# Altium schematic sheet isg_eos_control_board_xem3001.SchDoc
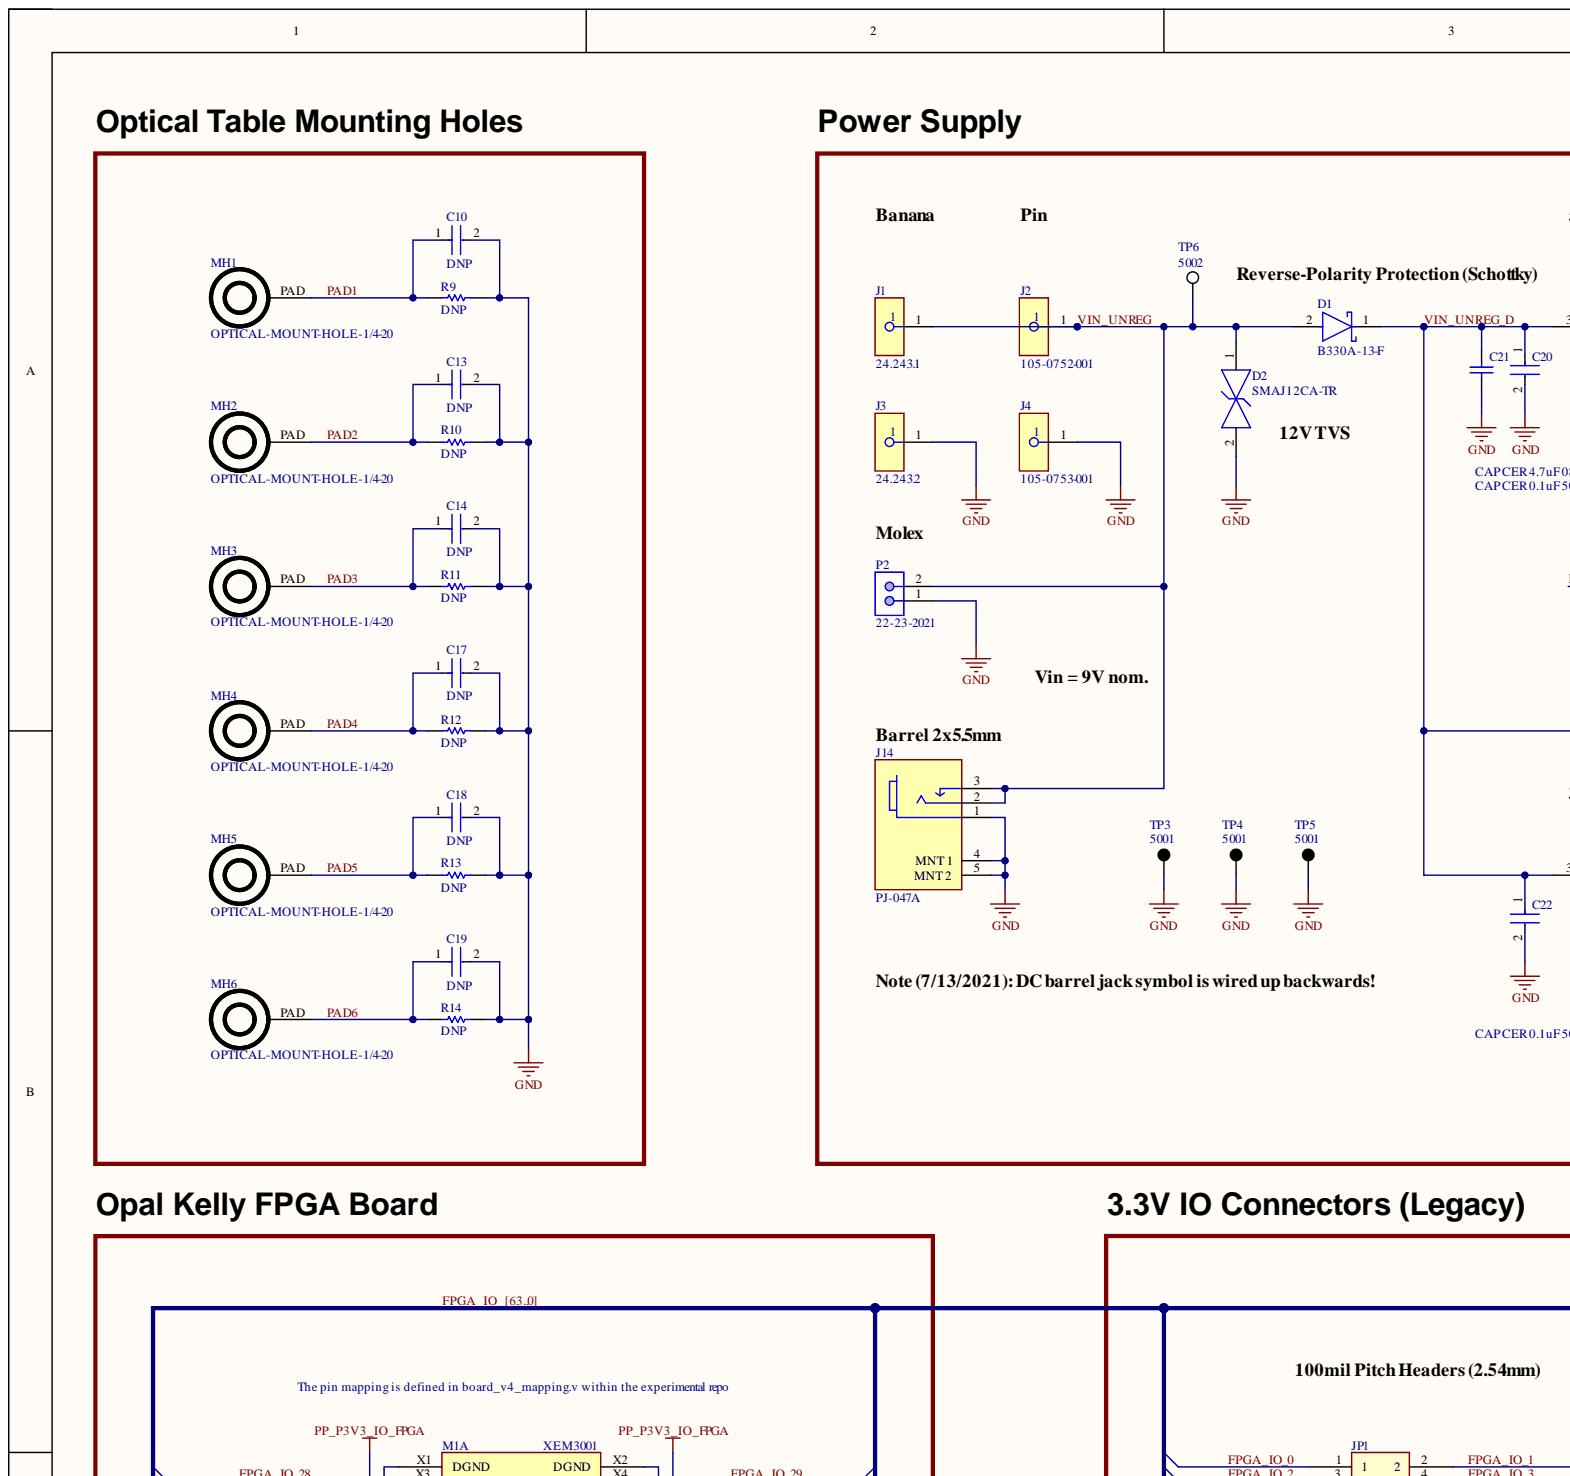
[diagram p0: isg_eos_control_board_xem3001.SchDoc, top left]
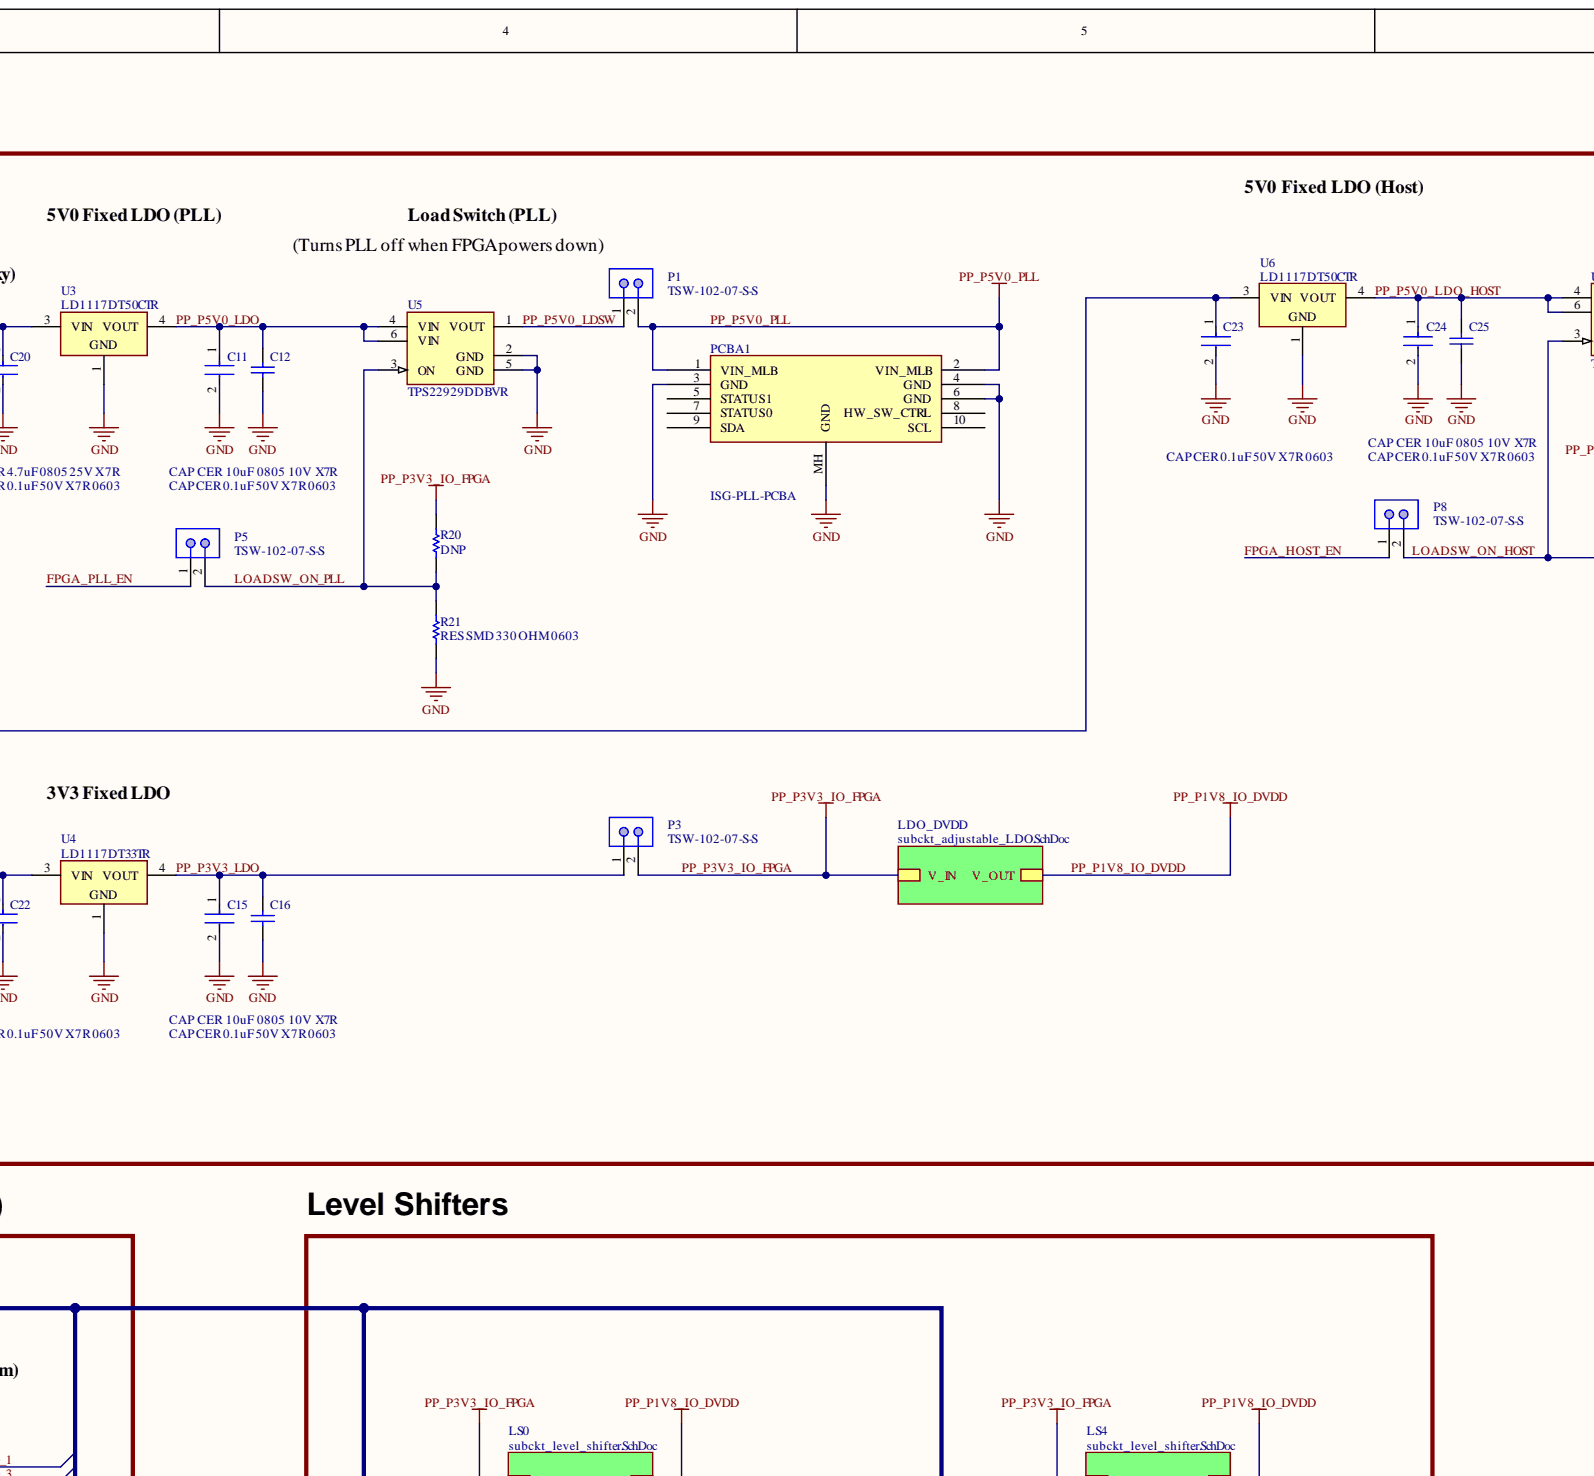
[diagram p1: isg_eos_control_board_xem3001.SchDoc, top centre]
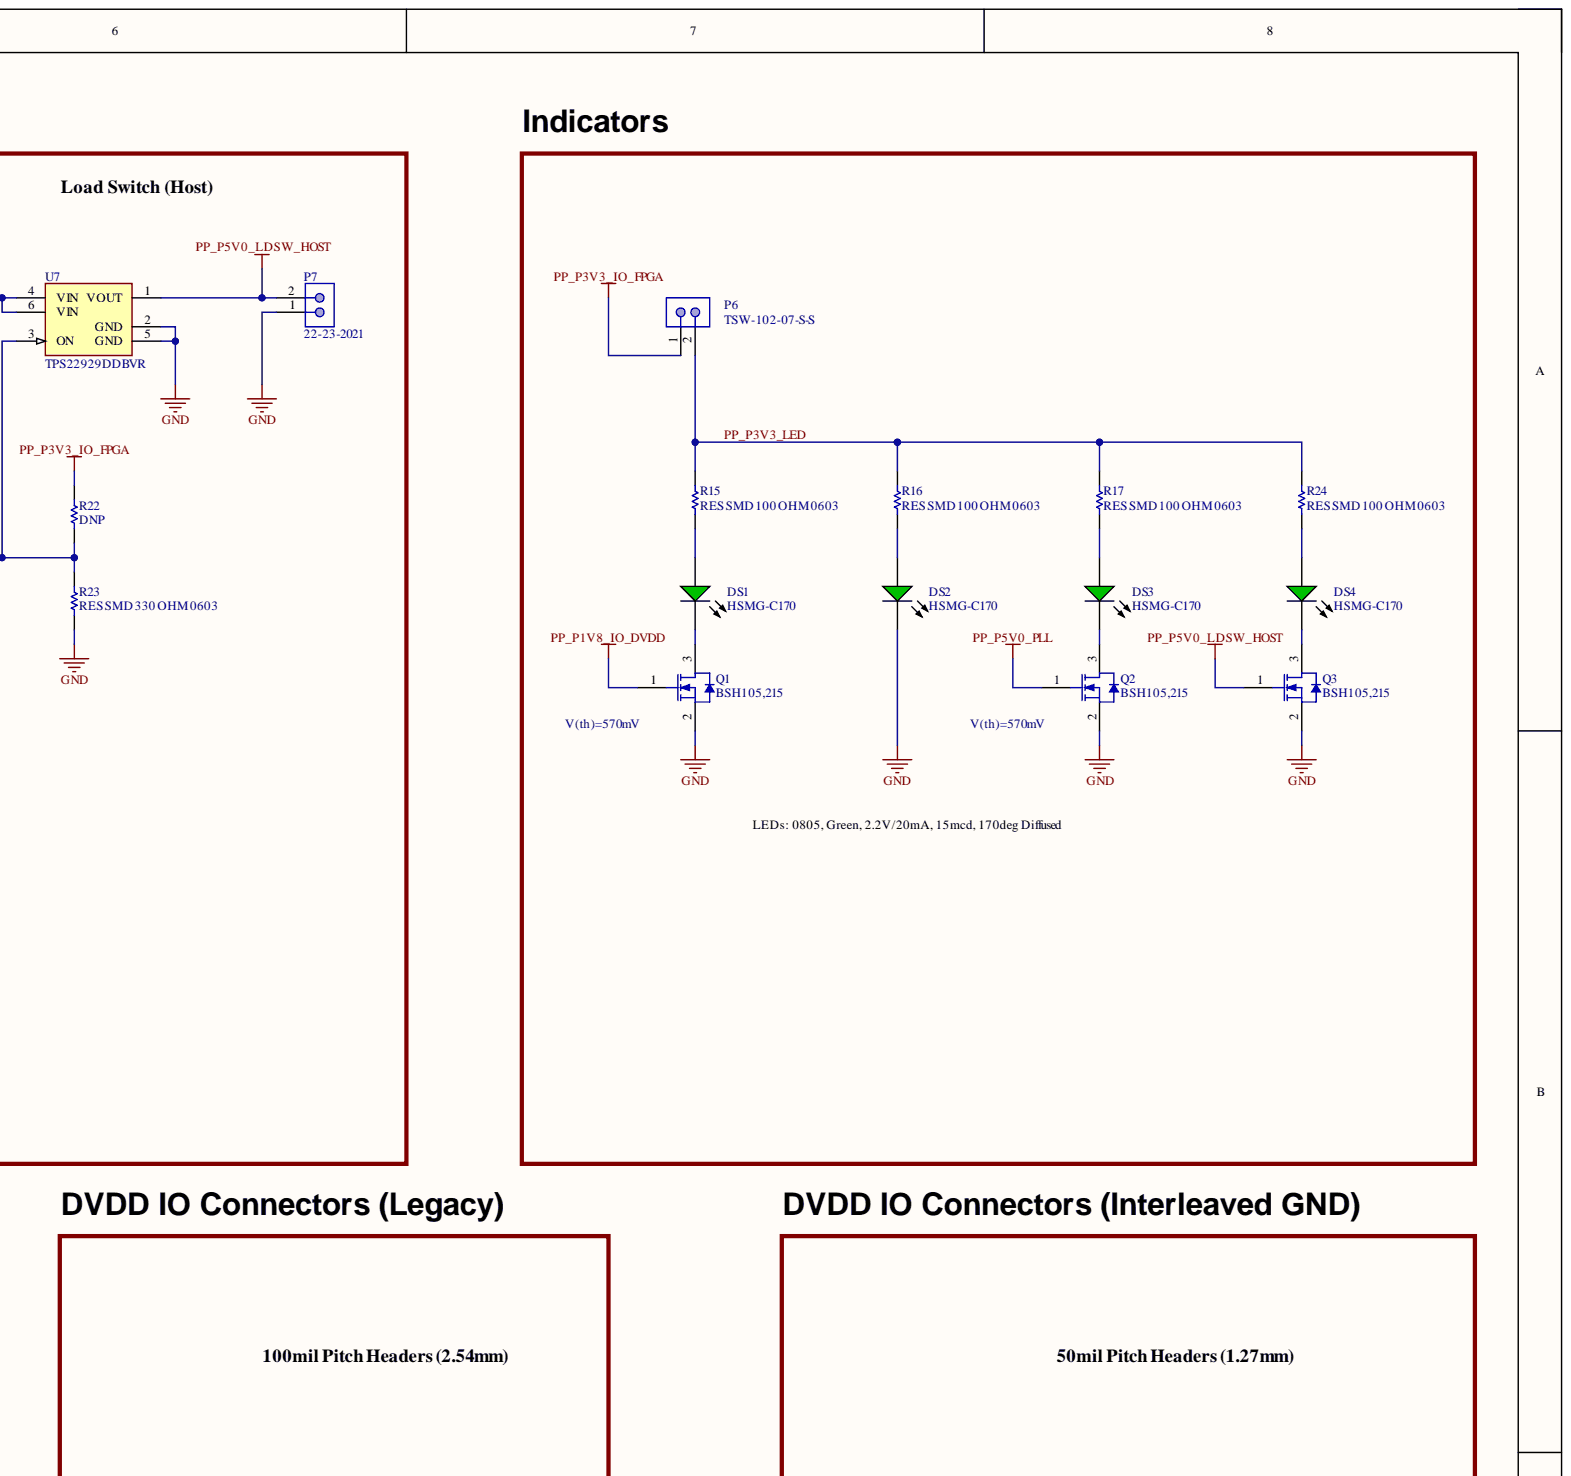
[diagram p2: isg_eos_control_board_xem3001.SchDoc, top right]
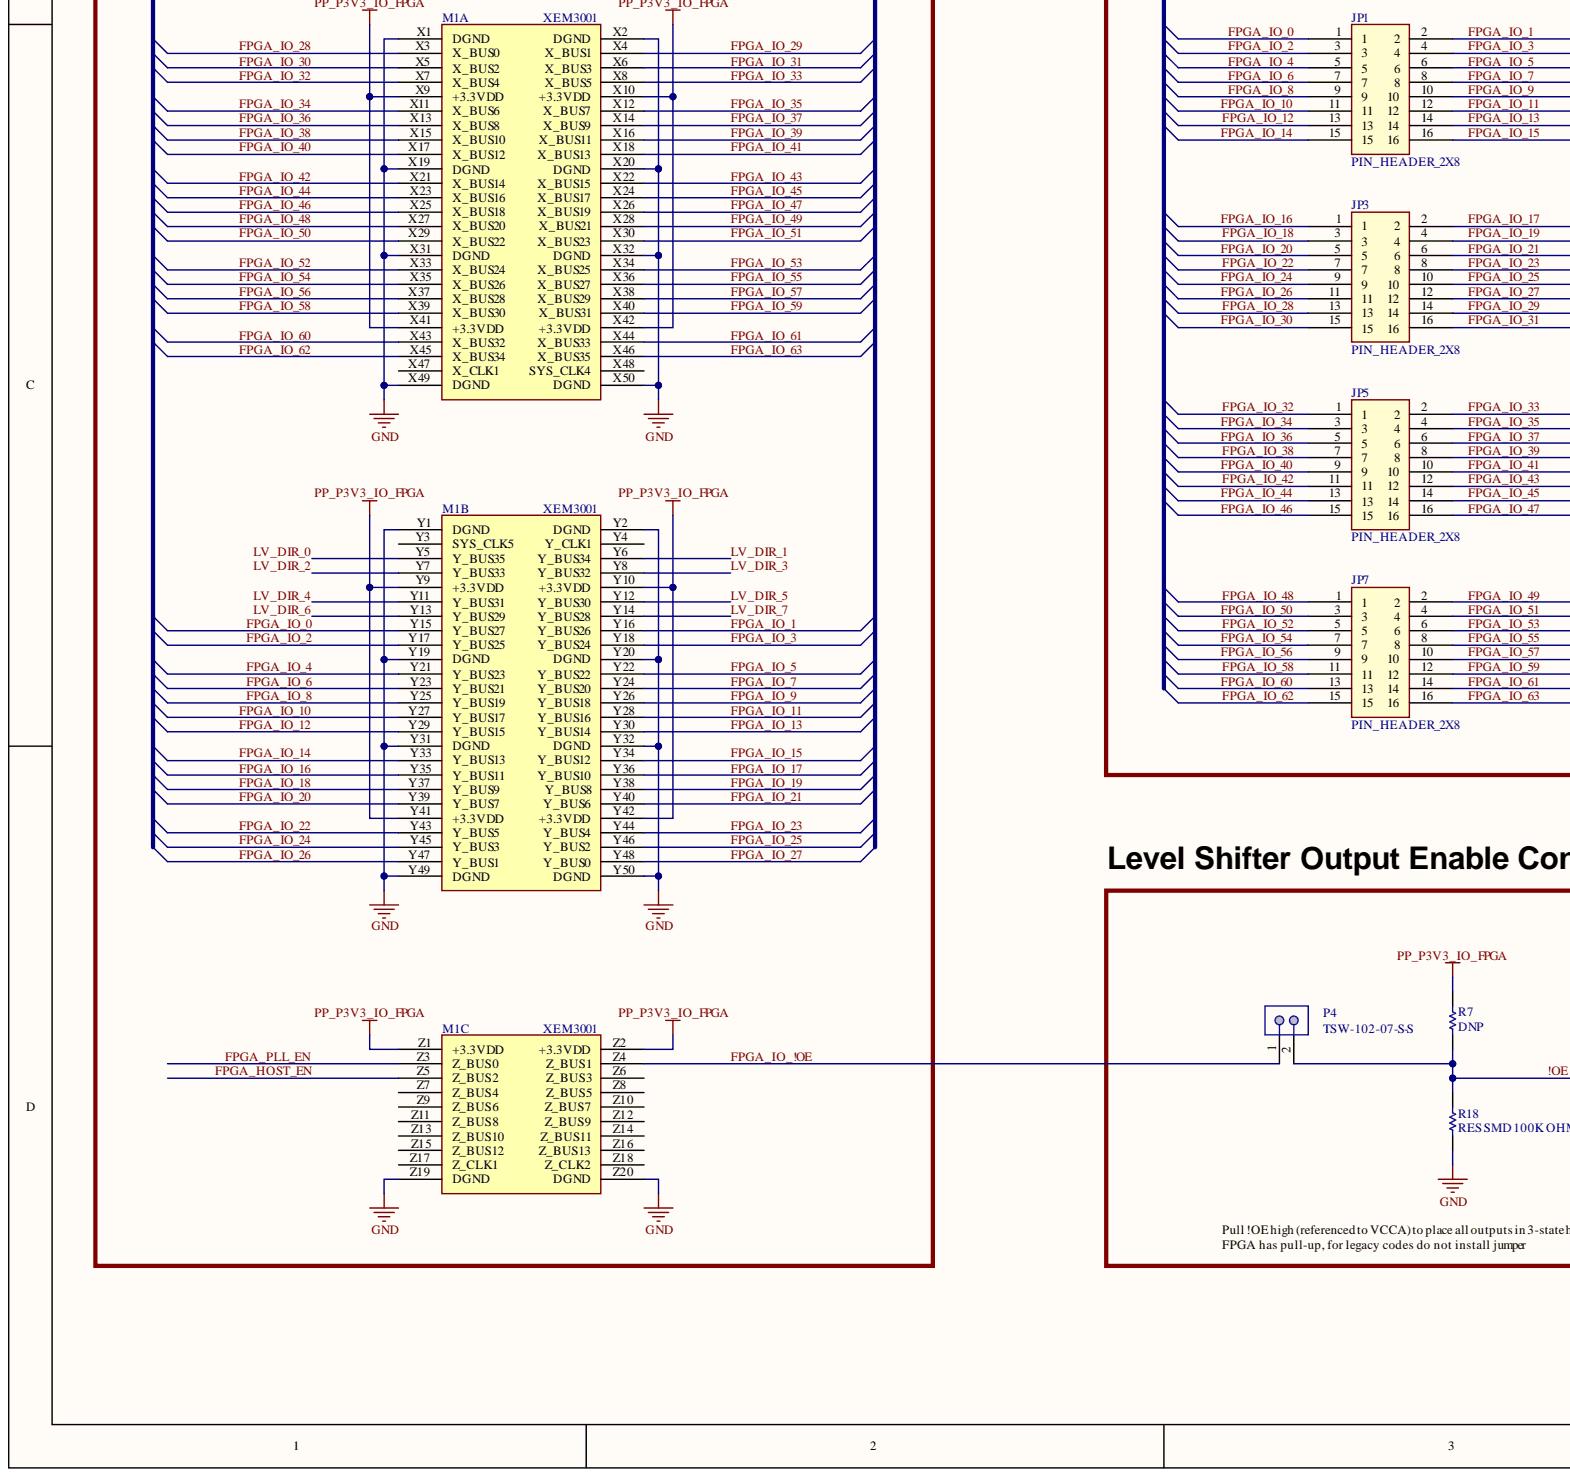
[diagram p3: isg_eos_control_board_xem3001.SchDoc, bottom left]
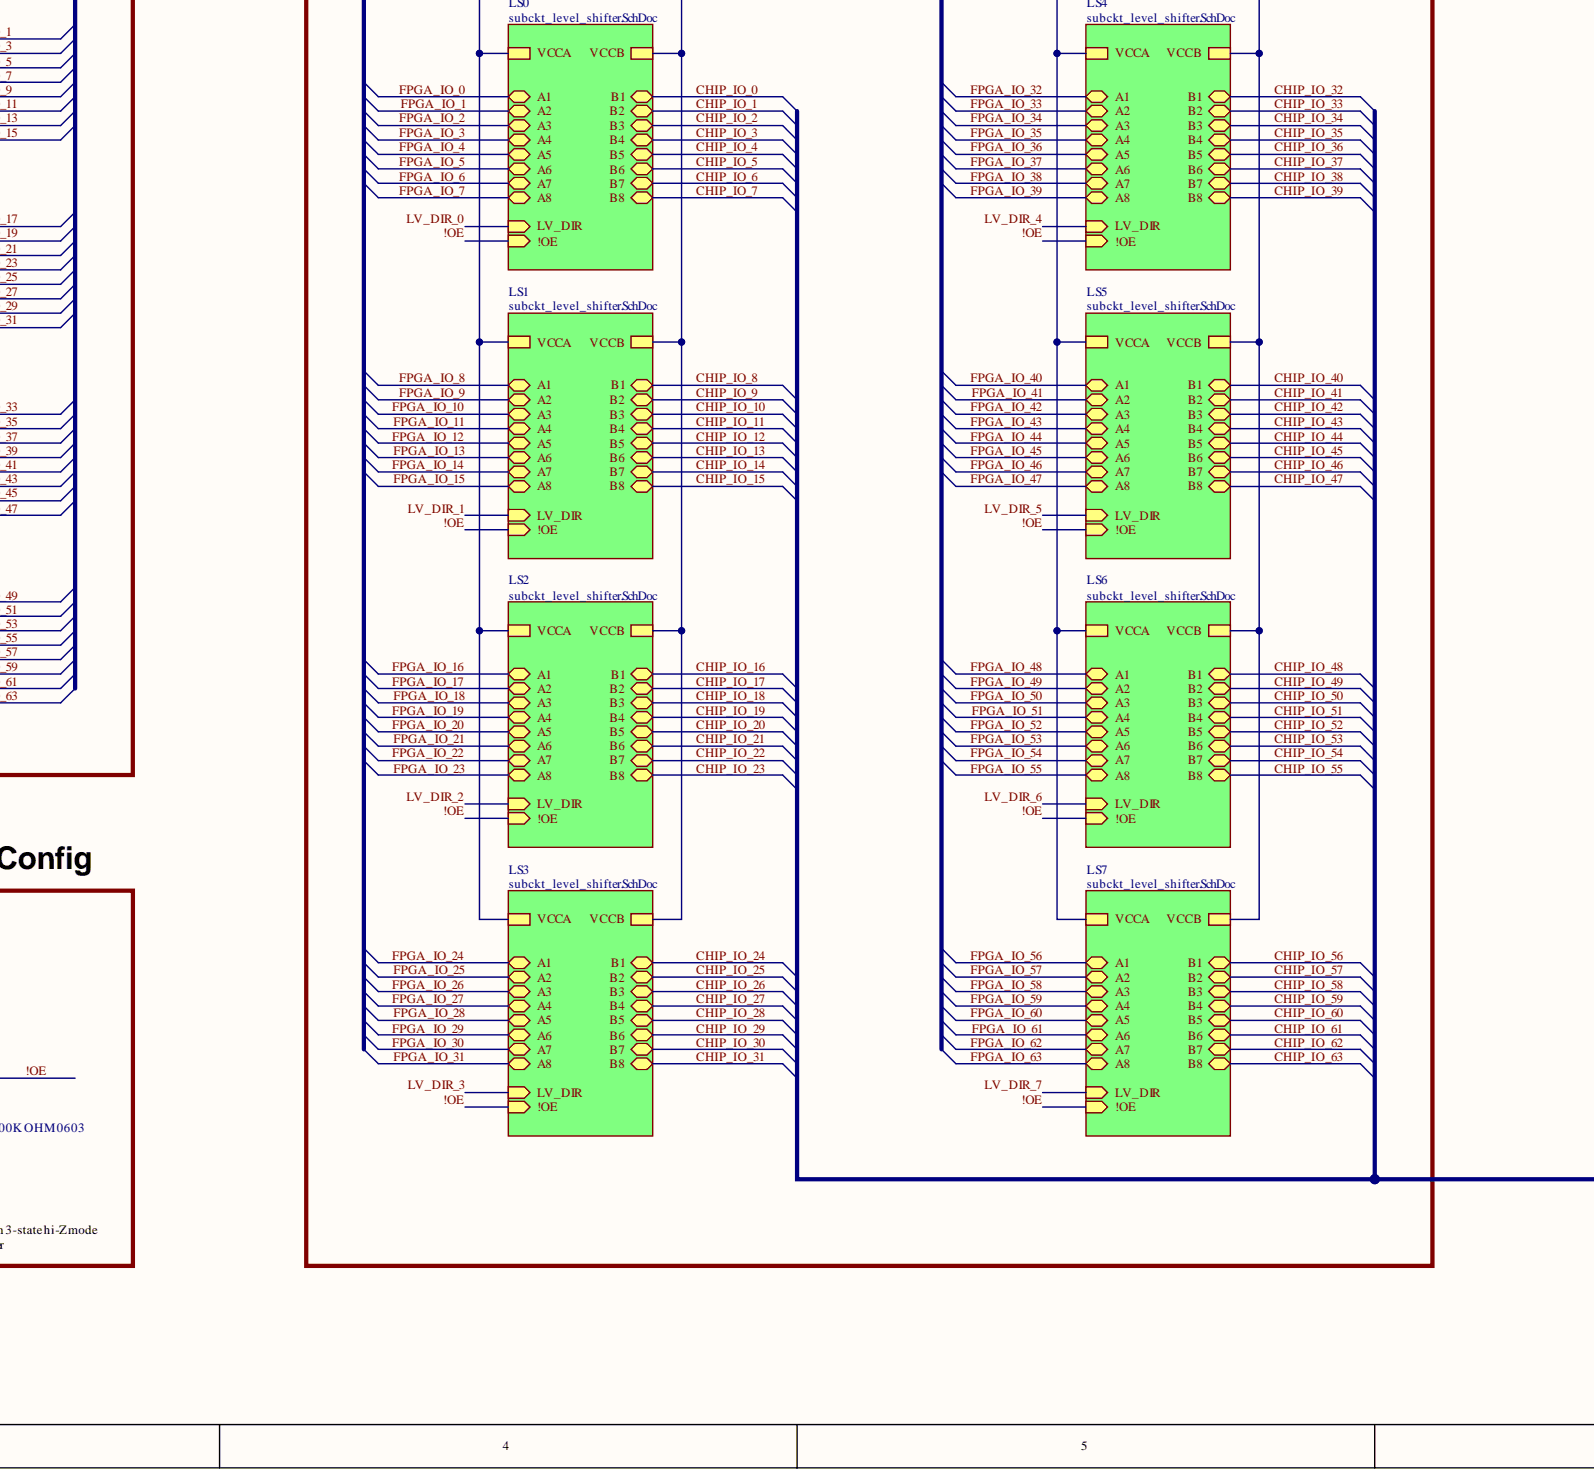
[diagram p4: isg_eos_control_board_xem3001.SchDoc, bottom centre]
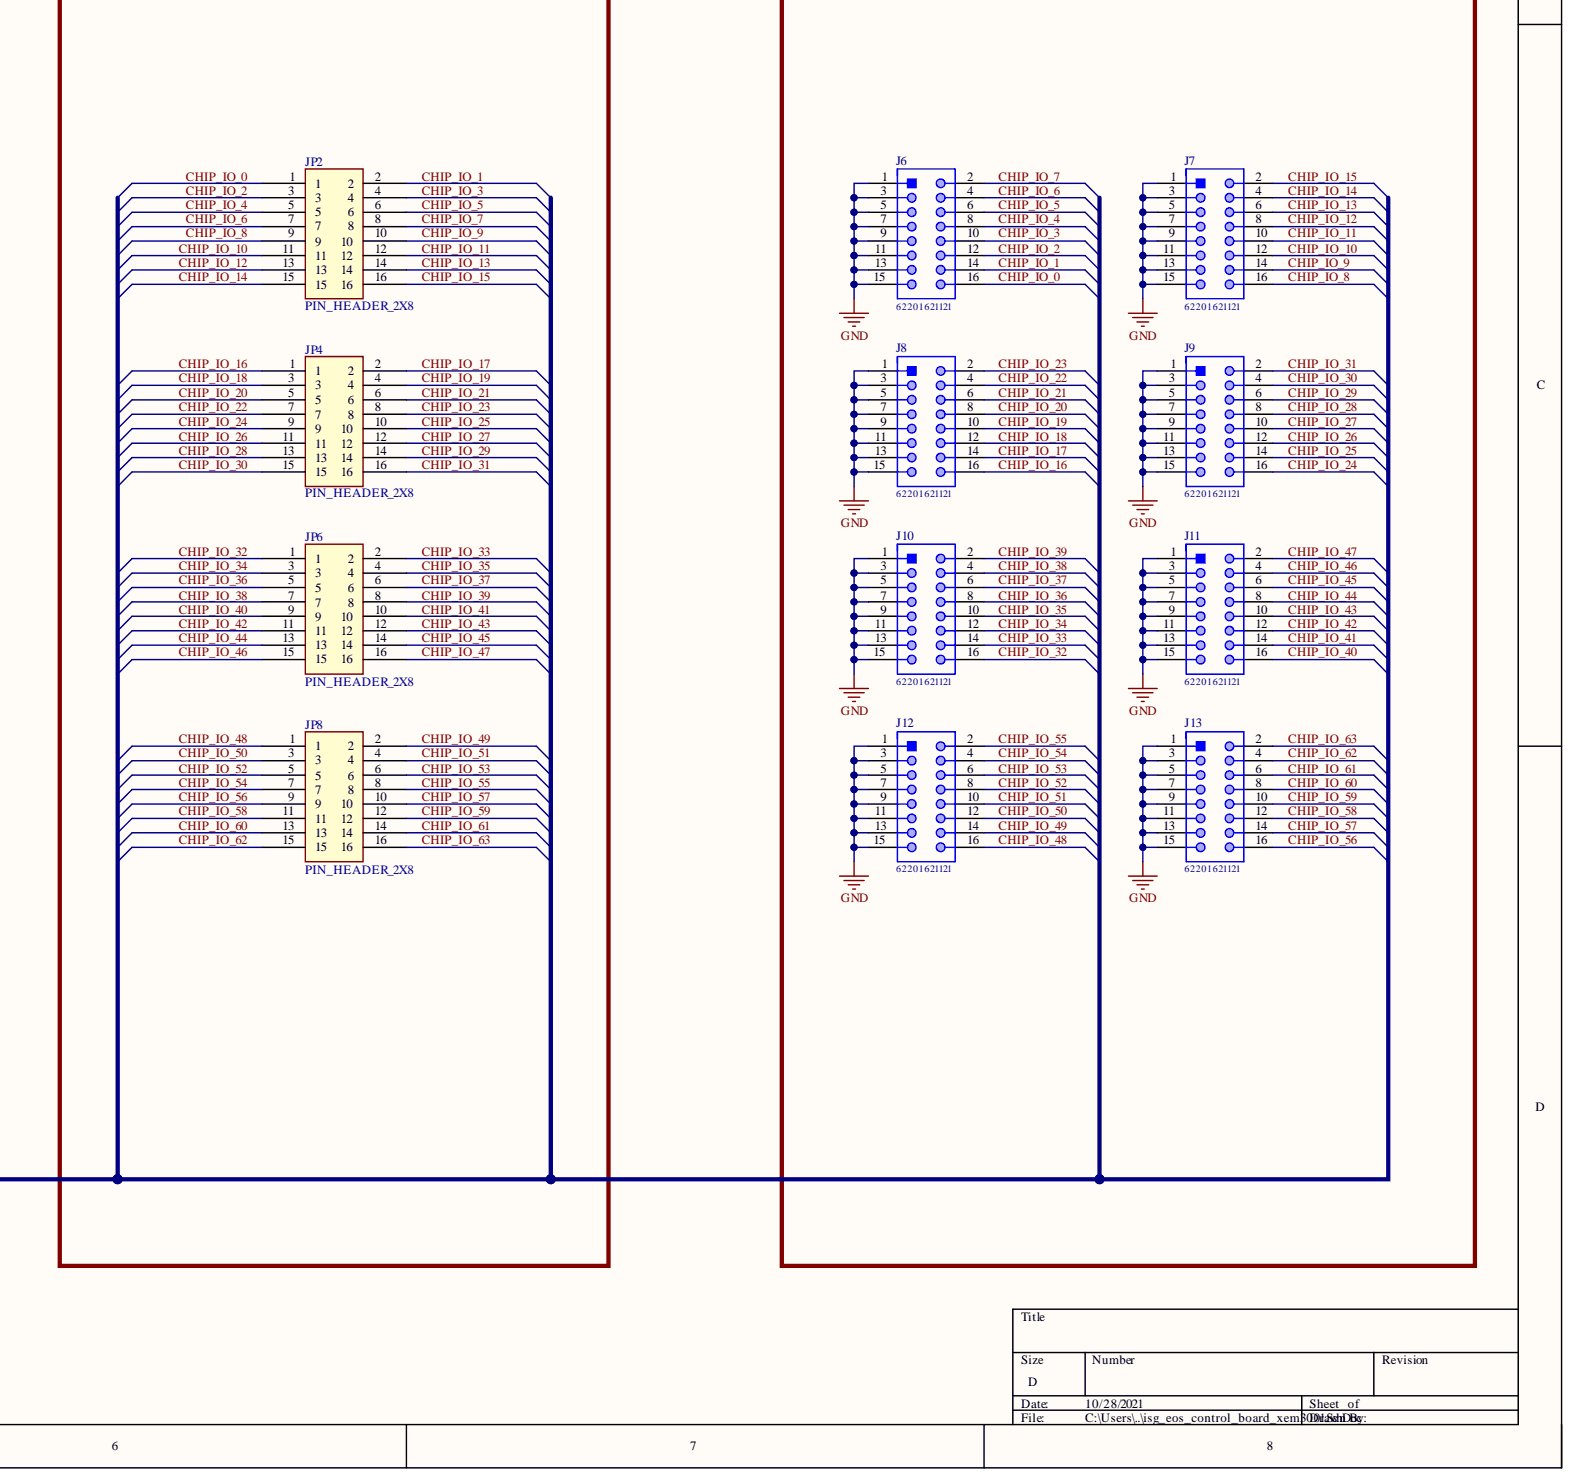
[diagram p5: isg_eos_control_board_xem3001.SchDoc, bottom right]

## Parts
C10  DNP  [FP-CC0603-DA-MFG]
C11  CAP CER 0.1uF 50V X7R 0603  [FP-CC0603-DA-MFG]
C12  CAP CER 10uF 0805 10V X7R  [CAPC2013X140X50NL20T25]
C13  DNP  [FP-CC0603-DA-MFG]
C14  DNP  [FP-CC0603-DA-MFG]
C15  CAP CER 0.1uF 50V X7R 0603  [FP-CC0603-DA-MFG]
C16  CAP CER 10uF 0805 10V X7R  [CAPC2013X140X50NL20T25]
C17  DNP  [FP-CC0603-DA-MFG]
C18  DNP  [FP-CC0603-DA-MFG]
C19  DNP  [FP-CC0603-DA-MFG]
C20  CAP CER 0.1uF 50V X7R 0603  [FP-CC0603-DA-MFG]
C21  CAP CER 4.7uF 0805 25V X7R  [CAPC2013X140X50NL20T25]
C22  CAP CER 0.1uF 50V X7R 0603  [FP-CC0603-DA-MFG]
C23  CAP CER 0.1uF 50V X7R 0603  [FP-CC0603-DA-MFG]
C24  CAP CER 0.1uF 50V X7R 0603  [FP-CC0603-DA-MFG]
C25  CAP CER 10uF 0805 10V X7R  [CAPC2013X140X50NL20T25]
D1  B330A-13-F  [FP-SMA-MFG]
D2  SMAJ12CA-TR  Reverse Standoff Voltage: 12V  [FP-SMA-CA-MFG]
DS1  HSMG-C170  [AVAG-HSMX-C170_V]
DS2  HSMG-C170  [AVAG-HSMX-C170_V]
DS3  HSMG-C170  [AVAG-HSMX-C170_V]
DS4  HSMG-C170  [AVAG-HSMX-C170_V]
J1  24.243.1  [FP-24_243_1-MFG]
J2  105-0752-001  [FP-105-0751-001-MFG]
J3  24.243.2  [FP-24_243_2-MFG]
J4  105-0753-001  [FP-105-0751-001-MFG]
J6  62201621121  [62201621121]
J7  62201621121  [62201621121]
J8  62201621121  [62201621121]
J9  62201621121  [62201621121]
J10  62201621121  [62201621121]
J11  62201621121  [62201621121]
J12  62201621121  [62201621121]
J13  62201621121  [62201621121]
J14  PJ-047A  [FP-PJ-047A-MFG]
JP1  PIN_HEADER_2X8  [PIN_HEADER_2X8_2.54MM]
JP2  PIN_HEADER_2X8  [PIN_HEADER_2X8_2.54MM]
JP3  PIN_HEADER_2X8  [PIN_HEADER_2X8_2.54MM]
JP4  PIN_HEADER_2X8  [PIN_HEADER_2X8_2.54MM]
JP5  PIN_HEADER_2X8  [PIN_HEADER_2X8_2.54MM]
JP6  PIN_HEADER_2X8  [PIN_HEADER_2X8_2.54MM]
JP7  PIN_HEADER_2X8  [PIN_HEADER_2X8_2.54MM]
JP8  PIN_HEADER_2X8  [PIN_HEADER_2X8_2.54MM]
M1  XEM3001  [XEM3001MODULE]  (parts A,B,C)
MH1  OPTICAL-MOUNT-HOLE-1/4-20  [MH-1/4-20]
MH2  OPTICAL-MOUNT-HOLE-1/4-20  [MH-1/4-20]
MH3  OPTICAL-MOUNT-HOLE-1/4-20  [MH-1/4-20]
MH4  OPTICAL-MOUNT-HOLE-1/4-20  [MH-1/4-20]
MH5  OPTICAL-MOUNT-HOLE-1/4-20  [MH-1/4-20]
MH6  OPTICAL-MOUNT-HOLE-1/4-20  [MH-1/4-20]
P1  TSW-102-07-S-S  [SMTC-TSW-102-07-S-S]
P2  22-23-2021  [MOLX-22-23-2021_V]
P3  TSW-102-07-S-S  [SMTC-TSW-102-07-S-S]
P4  TSW-102-07-S-S  [SMTC-TSW-102-07-S-S]
P5  TSW-102-07-S-S  [SMTC-TSW-102-07-S-S]
P6  TSW-102-07-S-S  [SMTC-TSW-102-07-S-S]
P7  22-23-2021  [MOLX-22-23-2021_V]
P8  TSW-102-07-S-S  [SMTC-TSW-102-07-S-S]
PCBA1  ISG-PLL-PCBA  [ISG-PLL-PCBA]
Q1  BSH105,215  [NXP-SOT23_M]
Q2  BSH105,215  [NXP-SOT23_M]
Q3  BSH105,215  [NXP-SOT23_M]
R7  DNP  [RESC1609X50X30LL10T20]
R9  DNP  [RESC1609X50X30LL10T20]
R10  DNP  [RESC1609X50X30LL10T20]
R11  DNP  [RESC1609X50X30LL10T20]
R12  DNP  [RESC1609X50X30LL10T20]
R13  DNP  [RESC1609X50X30LL10T20]
R14  DNP  [RESC1609X50X30LL10T20]
R15  RES SMD 100 OHM 0603  [RESC1609X50X30LL10T20]
R16  RES SMD 100 OHM 0603  [RESC1609X50X30LL10T20]
R17  RES SMD 100 OHM 0603  [RESC1609X50X30LL10T20]
R18  RES SMD 100K OHM 0603  [RESC1609X50X30LL10T20]
R20  DNP  [RESC1609X50X30LL10T20]
R21  RES SMD 330 OHM 0603  [RESC1609X50X30LL10T20]
R22  DNP  [RESC1609X50X30LL10T20]
R23  RES SMD 330 OHM 0603  [RESC1609X50X30LL10T20]
R24  RES SMD 100 OHM 0603  [RESC1609X50X30LL10T20]
TP3  5001  [KSTN-5001]
TP4  5001  [KSTN-5001]
TP5  5001  [KSTN-5001]
TP6  5002  [KSTN-5002]
U3  LD1117DT50CTR  [TO-252_N]
U4  LD1117DT33TR  [TO-252_N]
U5  TPS22929DDBVR  [DBV0006A_M]
U6  LD1117DT50CTR  [TO-252_N]
U7  TPS22929DDBVR  [DBV0006A_M]

## Nets
GND: C10.2 C11.2 C12.2 C13.2 C14.2 C15.2 C16.2 C17.2 C18.2 C19.2 C20.2 C21.2 C22.2 C23.2 C24.2 C25.2 D2.2 DS2.2 J3.1 J4.1 J6.1 J6.3 J6.5 J6.7 J6.9 J6.11 J6.13 J6.15 J7.1 J7.3 J7.5 J7.7 J7.9 J7.11 J7.13 J7.15 J8.1 J8.3 J8.5 J8.7 J8.9 J8.11 J8.13 J8.15 J9.1 J9.3 J9.5 J9.7 J9.9 J9.11 J9.13 J9.15 J10.1 J10.3 J10.5 J10.7 J10.9 J10.11 J10.13 J10.15 J11.1 J11.3 J11.5 J11.7 J11.9 J11.11 J11.13 J11.15 J12.1 J12.3 J12.5 J12.7 J12.9 J12.11 J12.13 J12.15 J13.1 J13.3 J13.5 J13.7 J13.9 J13.11 J13.13 J13.15 J14.1 J14.4(MNT_1) J14.5(MNT_2) M1.X1(DGND) M1.X2(DGND) M1.X19(DGND) M1.X20(DGND) M1.X31(DGND) M1.X32(DGND) M1.X49(DGND) M1.X50(DGND) M1.Y1(DGND) M1.Y2(DGND) M1.Y19(DGND) M1.Y20(DGND) M1.Y31(DGND) M1.Y32(DGND) M1.Y49(DGND) M1.Y50(DGND) M1.Z19(DGND) M1.Z20(DGND) P2.1 P7.1 PCBA1.3(GND) PCBA1.4(GND) PCBA1.6(GND) PCBA1.MH(GND) Q1.2 Q2.2 Q3.2 R9.2 R10.2 R11.2 R12.2 R13.2 R14.2 R18.2 R21.2 R23.2 TP3.1 TP4.1 TP5.1 U3.1 U4.1 U5.2(GND) U5.5(GND) U6.1 U7.2(GND) U7.5(GND)
PP_P5V0_LDSW_HOST: P7.2 Q3.1 U7.1(VOUT)
!OE: P4.2 R7.2 R18.1
CHIP_IO_0: J6.16 JP2.1
CHIP_IO_1: J6.14 JP2.2
CHIP_IO_2: J6.12 JP2.3
CHIP_IO_3: J6.10 JP2.4
CHIP_IO_4: J6.8 JP2.5
CHIP_IO_5: J6.6 JP2.6
CHIP_IO_6: J6.4 JP2.7
CHIP_IO_7: J6.2 JP2.8
CHIP_IO_8: J7.16 JP2.9
CHIP_IO_9: J7.14 JP2.10
CHIP_IO_10: J7.12 JP2.11
CHIP_IO_11: J7.10 JP2.12
CHIP_IO_12: J7.8 JP2.13
CHIP_IO_13: J7.6 JP2.14
CHIP_IO_14: J7.4 JP2.15
CHIP_IO_15: J7.2 JP2.16
CHIP_IO_16: J8.16 JP4.1
CHIP_IO_17: J8.14 JP4.2
CHIP_IO_18: J8.12 JP4.3
CHIP_IO_19: J8.10 JP4.4
CHIP_IO_20: J8.8 JP4.5
CHIP_IO_21: J8.6 JP4.6
CHIP_IO_22: J8.4 JP4.7
CHIP_IO_23: J8.2 JP4.8
CHIP_IO_24: J9.16 JP4.9
CHIP_IO_25: J9.14 JP4.10
CHIP_IO_26: J9.12 JP4.11
CHIP_IO_27: J9.10 JP4.12
CHIP_IO_28: J9.8 JP4.13
CHIP_IO_29: J9.6 JP4.14
CHIP_IO_30: J9.4 JP4.15
CHIP_IO_31: J9.2 JP4.16
CHIP_IO_32: J10.16 JP6.1
CHIP_IO_33: J10.14 JP6.2
CHIP_IO_34: J10.12 JP6.3
CHIP_IO_35: J10.10 JP6.4
CHIP_IO_36: J10.8 JP6.5
CHIP_IO_37: J10.6 JP6.6
CHIP_IO_38: J10.4 JP6.7
CHIP_IO_39: J10.2 JP6.8
CHIP_IO_40: J11.16 JP6.9
CHIP_IO_41: J11.14 JP6.10
CHIP_IO_42: J11.12 JP6.11
CHIP_IO_43: J11.10 JP6.12
CHIP_IO_44: J11.8 JP6.13
CHIP_IO_45: J11.6 JP6.14
CHIP_IO_46: J11.4 JP6.15
CHIP_IO_47: J11.2 JP6.16
CHIP_IO_48: J12.16 JP8.1
CHIP_IO_49: J12.14 JP8.2
CHIP_IO_50: J12.12 JP8.3
CHIP_IO_51: J12.10 JP8.4
CHIP_IO_52: J12.8 JP8.5
CHIP_IO_53: J12.6 JP8.6
CHIP_IO_54: J12.4 JP8.7
CHIP_IO_55: J12.2 JP8.8
CHIP_IO_56: J13.16 JP8.9
CHIP_IO_57: J13.14 JP8.10
CHIP_IO_58: J13.12 JP8.11
CHIP_IO_59: J13.10 JP8.12
CHIP_IO_60: J13.8 JP8.13
CHIP_IO_61: J13.6 JP8.14
CHIP_IO_62: J13.4 JP8.15
CHIP_IO_63: J13.2 JP8.16
FPGA_HOST_EN: M1.Z5(Z_BUS2) P8.1
FPGA_IO_0: JP1.1 M1.Y15(Y_BUS27)  [also labelled FPGA_IO_]
FPGA_IO_1: JP1.2 M1.Y16(Y_BUS26)  [also labelled FPGA_IO_]
FPGA_IO_2: JP1.3 M1.Y17(Y_BUS25)  [also labelled FPGA_IO_]
FPGA_IO_3: JP1.4 M1.Y18(Y_BUS24)  [also labelled FPGA_IO_]
FPGA_IO_4: JP1.5 M1.Y21(Y_BUS23)  [also labelled FPGA_IO_]
FPGA_IO_5: JP1.6 M1.Y22(Y_BUS22)  [also labelled FPGA_IO_]
FPGA_IO_6: JP1.7 M1.Y23(Y_BUS21)  [also labelled FPGA_IO_]
FPGA_IO_7: JP1.8 M1.Y24(Y_BUS20)  [also labelled FPGA_IO_]
FPGA_IO_8: JP1.9 M1.Y25(Y_BUS19)  [also labelled FPGA_IO_]
FPGA_IO_9: JP1.10 M1.Y26(Y_BUS18)  [also labelled FPGA_IO_]
FPGA_IO_10: JP1.11 M1.Y27(Y_BUS17)  [also labelled FPGA_IO_]
FPGA_IO_11: JP1.12 M1.Y28(Y_BUS16)  [also labelled FPGA_IO_]
FPGA_IO_12: JP1.13 M1.Y29(Y_BUS15)  [also labelled FPGA_IO_]
FPGA_IO_13: JP1.14 M1.Y30(Y_BUS14)  [also labelled FPGA_IO_]
FPGA_IO_14: JP1.15 M1.Y33(Y_BUS13)  [also labelled FPGA_IO_]
FPGA_IO_15: JP1.16 M1.Y34(Y_BUS12)  [also labelled FPGA_IO_]
FPGA_IO_16: JP3.1 M1.Y35(Y_BUS11)  [also labelled FPGA_IO_]
FPGA_IO_17: JP3.2 M1.Y36(Y_BUS10)  [also labelled FPGA_IO_]
FPGA_IO_18: JP3.3 M1.Y37(Y_BUS9)  [also labelled FPGA_IO_]
FPGA_IO_19: JP3.4 M1.Y38(Y_BUS8)  [also labelled FPGA_IO_]
FPGA_IO_20: JP3.5 M1.Y39(Y_BUS7)  [also labelled FPGA_IO_]
FPGA_IO_21: JP3.6 M1.Y40(Y_BUS6)  [also labelled FPGA_IO_]
FPGA_IO_22: JP3.7 M1.Y43(Y_BUS5)  [also labelled FPGA_IO_]
FPGA_IO_23: JP3.8 M1.Y44(Y_BUS4)  [also labelled FPGA_IO_]
FPGA_IO_24: JP3.9 M1.Y45(Y_BUS3)  [also labelled FPGA_IO_]
FPGA_IO_25: JP3.10 M1.Y46(Y_BUS2)  [also labelled FPGA_IO_]
FPGA_IO_26: JP3.11 M1.Y47(Y_BUS1)  [also labelled FPGA_IO_]
FPGA_IO_27: JP3.12 M1.Y48(Y_BUS0)  [also labelled FPGA_IO_]
FPGA_IO_28: JP3.13 M1.X3(X_BUS0)  [also labelled FPGA_IO_]
FPGA_IO_29: JP3.14 M1.X4(X_BUS1)  [also labelled FPGA_IO_]
FPGA_IO_30: JP3.15 M1.X5(X_BUS2)  [also labelled FPGA_IO_]
FPGA_IO_31: JP3.16 M1.X6(X_BUS3)  [also labelled FPGA_IO_]
FPGA_IO_32: JP5.1 M1.X7(X_BUS4)  [also labelled FPGA_IO_]
FPGA_IO_33: JP5.2 M1.X8(X_BUS5)  [also labelled FPGA_IO_]
FPGA_IO_34: JP5.3 M1.X11(X_BUS6)  [also labelled FPGA_IO_]
FPGA_IO_35: JP5.4 M1.X12(X_BUS7)  [also labelled FPGA_IO_]
FPGA_IO_36: JP5.5 M1.X13(X_BUS8)  [also labelled FPGA_IO_]
FPGA_IO_37: JP5.6 M1.X14(X_BUS9)  [also labelled FPGA_IO_]
FPGA_IO_38: JP5.7 M1.X15(X_BUS10)  [also labelled FPGA_IO_]
FPGA_IO_39: JP5.8 M1.X16(X_BUS11)  [also labelled FPGA_IO_]
FPGA_IO_40: JP5.9 M1.X17(X_BUS12)  [also labelled FPGA_IO_]
FPGA_IO_41: JP5.10 M1.X18(X_BUS13)  [also labelled FPGA_IO_]
FPGA_IO_42: JP5.11 M1.X21(X_BUS14)  [also labelled FPGA_IO_]
FPGA_IO_43: JP5.12 M1.X22(X_BUS15)  [also labelled FPGA_IO_]
FPGA_IO_44: JP5.13 M1.X23(X_BUS16)  [also labelled FPGA_IO_]
FPGA_IO_45: JP5.14 M1.X24(X_BUS17)  [also labelled FPGA_IO_]
FPGA_IO_46: JP5.15 M1.X25(X_BUS18)  [also labelled FPGA_IO_]
FPGA_IO_47: JP5.16 M1.X26(X_BUS19)  [also labelled FPGA_IO_]
FPGA_IO_48: JP7.1 M1.X27(X_BUS20)  [also labelled FPGA_IO_]
FPGA_IO_49: JP7.2 M1.X28(X_BUS21)  [also labelled FPGA_IO_]
FPGA_IO_50: JP7.3 M1.X29(X_BUS22)  [also labelled FPGA_IO_]
FPGA_IO_51: JP7.4 M1.X30(X_BUS23)  [also labelled FPGA_IO_]
FPGA_IO_52: JP7.5 M1.X33(X_BUS24)  [also labelled FPGA_IO_]
FPGA_IO_53: JP7.6 M1.X34(X_BUS25)  [also labelled FPGA_IO_]
FPGA_IO_54: JP7.7 M1.X35(X_BUS26)  [also labelled FPGA_IO_]
FPGA_IO_55: JP7.8 M1.X36(X_BUS27)  [also labelled FPGA_IO_]
FPGA_IO_56: JP7.9 M1.X37(X_BUS28)  [also labelled FPGA_IO_]
FPGA_IO_57: JP7.10 M1.X38(X_BUS29)  [also labelled FPGA_IO_]
FPGA_IO_58: JP7.11 M1.X39(X_BUS30)  [also labelled FPGA_IO_]
FPGA_IO_59: JP7.12 M1.X40(X_BUS31)  [also labelled FPGA_IO_]
FPGA_IO_60: JP7.13 M1.X43(X_BUS32)  [also labelled FPGA_IO_]
FPGA_IO_61: JP7.14 M1.X44(X_BUS33)  [also labelled FPGA_IO_]
FPGA_IO_62: JP7.15 M1.X45(X_BUS34)  [also labelled FPGA_IO_]
FPGA_IO_63: JP7.16 M1.X46(X_BUS35)  [also labelled FPGA_IO_]
FPGA_IO_!OE: M1.Z4(Z_BUS1) P4.1
FPGA_PLL_EN: M1.Z3(Z_BUS0) P5.1
LOADSW_ON_HOST: P8.2 R22.2 R23.1 U7.3(ON)
LOADSW_ON_PLL: P5.2 R20.2 R21.1 U5.3(ON)
LV_DIR_0: M1.Y5(Y_BUS35)
LV_DIR_1: M1.Y6(Y_BUS34)
LV_DIR_2: M1.Y7(Y_BUS33)
LV_DIR_3: M1.Y8(Y_BUS32)
LV_DIR_4: M1.Y11(Y_BUS31)
LV_DIR_5: M1.Y12(Y_BUS30)
LV_DIR_6: M1.Y13(Y_BUS29)
LV_DIR_7: M1.Y14(Y_BUS28)
PAD1: C10.1 MH1.PAD R9.1
PAD2: C13.1 MH2.PAD R10.1
PAD3: C14.1 MH3.PAD R11.1
PAD4: C17.1 MH4.PAD R12.1
PAD5: C18.1 MH5.PAD R13.1
PAD6: C19.1 MH6.PAD R14.1
PP_P1V8_IO_DVDD: Q1.1
PP_P3V3_IO_FPGA: M1.X9(+3.3VDD) M1.X10(+3.3VDD) M1.X41(+3.3VDD) M1.X42(+3.3VDD) M1.Y9(+3.3VDD) M1.Y10(+3.3VDD) M1.Y41(+3.3VDD) M1.Y42(+3.3VDD) M1.Z1(+3.3VDD) M1.Z2(+3.3VDD) P3.2 P6.1 R7.1 R20.1 R22.1
PP_P3V3_LDO: C15.1 C16.1 P3.1 U4.4
PP_P3V3_LED: P6.2 R15.2 R16.2 R17.2 R24.2
PP_P5V0_LDO: C11.1 C12.1 U3.4 U5.4(VIN) U5.6(VIN)
PP_P5V0_LDO_HOST: C24.1 C25.1 U6.4 U7.4(VIN) U7.6(VIN)
PP_P5V0_LDSW: P1.1 U5.1(VOUT)
PP_P5V0_PLL: P1.2 PCBA1.1(VIN_MLB) PCBA1.2(VIN_MLB) Q2.1
VIN_UNREG: D1.2 D2.1 J1.1 J2.1 J14.2 J14.3 P2.2 TP6.1
VIN_UNREG_D: C20.1 C21.1 C22.1 C23.1 D1.1 U3.3 U4.3 U6.3
NetDS1_1: DS1.1 R15.1
NetDS1_2: DS1.2 Q1.3
NetDS2_1: DS2.1 R16.1
NetDS3_1: DS3.1 R17.1
NetDS3_2: DS3.2 Q2.3
NetDS4_1: DS4.1 R24.1
NetDS4_2: DS4.2 Q3.3
NetM1_X47: M1.X47(X_CLK1)
NetM1_X48: M1.X48(SYS_CLK4)
NetM1_Y3: M1.Y3(SYS_CLK5)
NetM1_Y4: M1.Y4(Y_CLK1)
NetM1_Z6: M1.Z6(Z_BUS3)
NetM1_Z7: M1.Z7(Z_BUS4)
NetM1_Z8: M1.Z8(Z_BUS5)
NetM1_Z9: M1.Z9(Z_BUS6)
NetM1_Z10: M1.Z10(Z_BUS7)
NetM1_Z11: M1.Z11(Z_BUS8)
NetM1_Z12: M1.Z12(Z_BUS9)
NetM1_Z13: M1.Z13(Z_BUS10)
NetM1_Z14: M1.Z14(Z_BUS11)
NetM1_Z15: M1.Z15(Z_BUS12)
NetM1_Z16: M1.Z16(Z_BUS13)
NetM1_Z17: M1.Z17(Z_CLK1)
NetM1_Z18: M1.Z18(Z_CLK2)
NetPCBA1_5: PCBA1.5(STATUS1)
NetPCBA1_7: PCBA1.7(STATUS0)
NetPCBA1_8: PCBA1.8(HW_SW_CTRL)
NetPCBA1_9: PCBA1.9(SDA)
NetPCBA1_10: PCBA1.10(SCL)
